# Altium schematic sheet ESP32.SchDoc(ESP32)
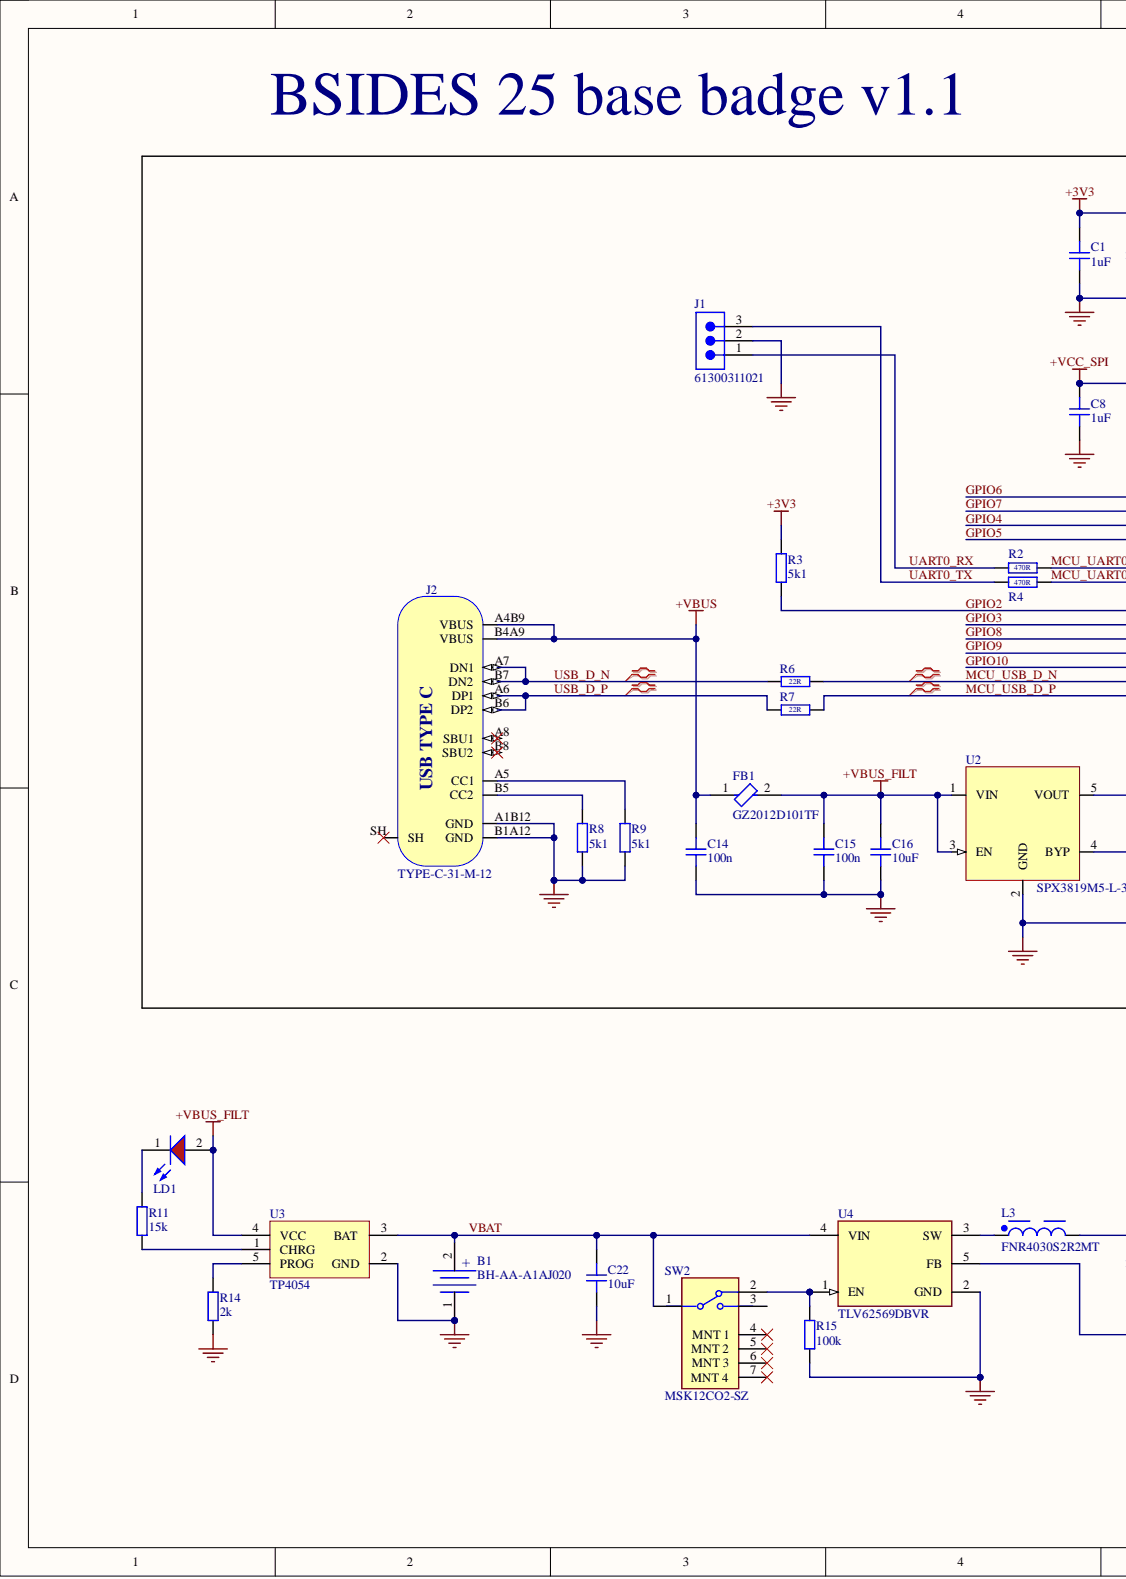
[diagram p0: ESP32.SchDoc, left]
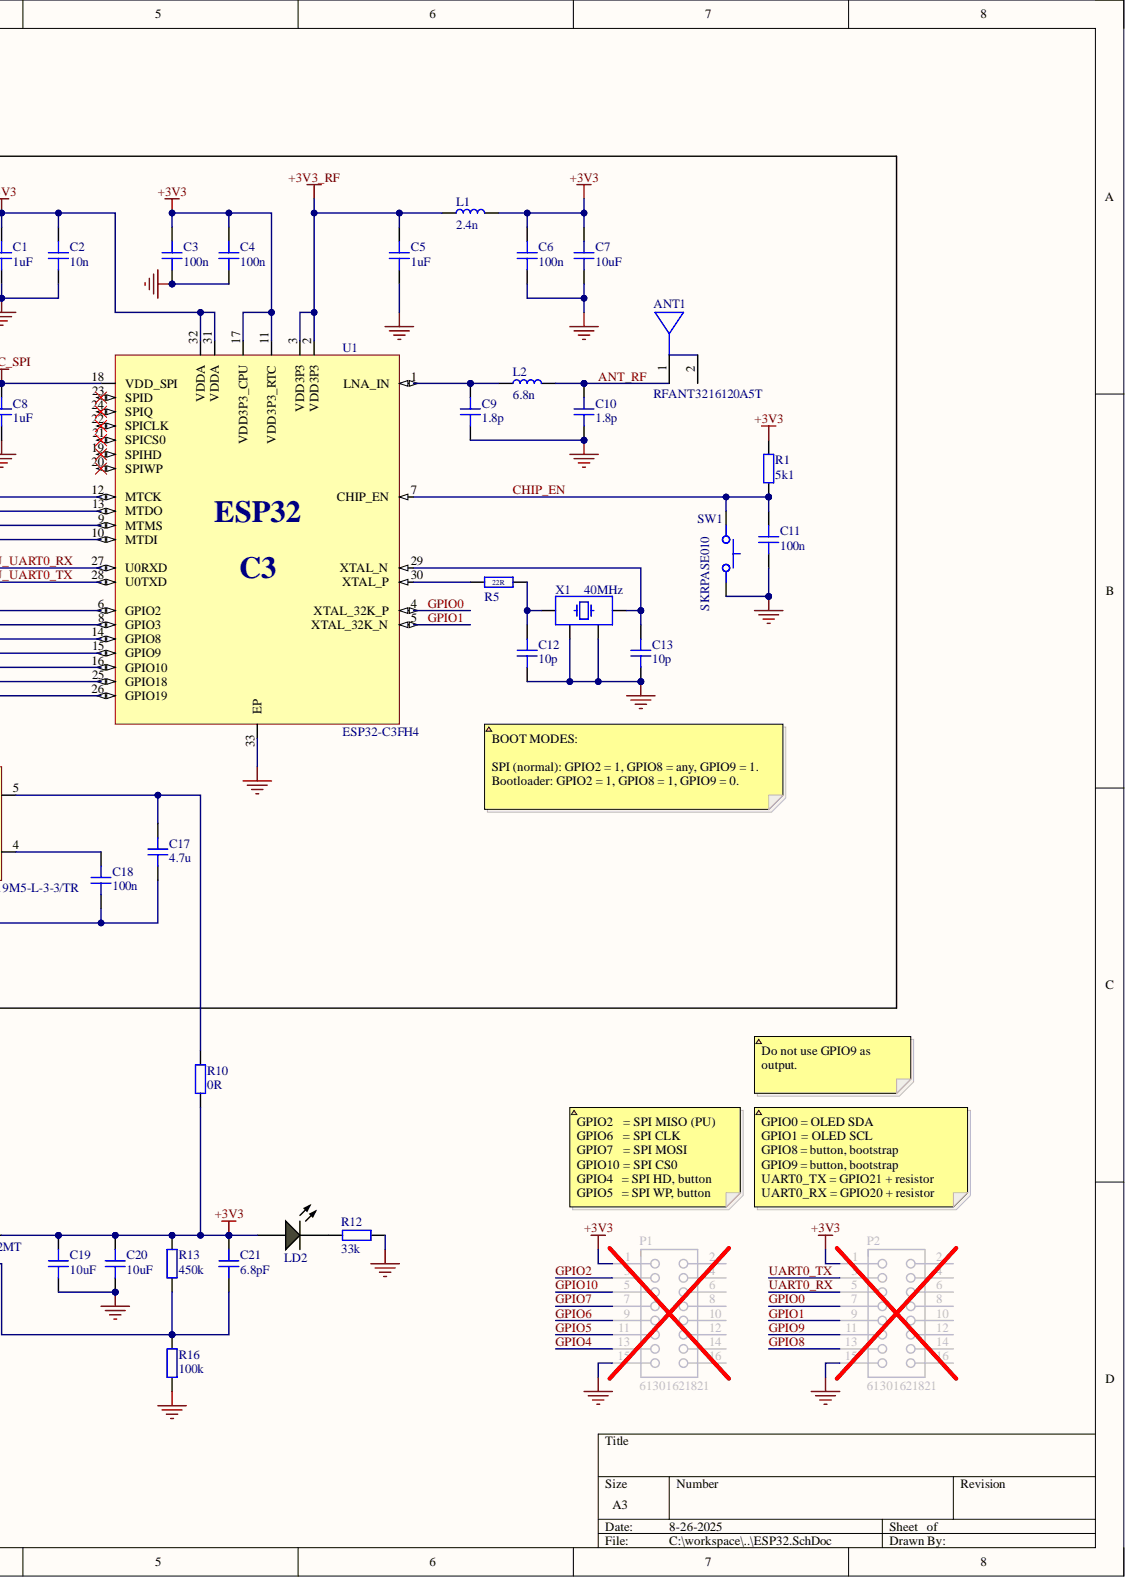
[diagram p1: ESP32.SchDoc, right]

## Parts
ANT1  RFANT3216120A5T  [FP-RFANT3216120A5T-MFG]
B1  BH-AA-A1AJ020  [BH-AA-A1AJ020]
C1  1uF  [CAP_0402]
C2  10n  [CAP_0402]
C3  100n  [CAP_0402]
C4  100n  [CAP_0402]
C5  1uF  [CAP_0402]
C6  100n  [CAP_0402]
C7  10uF  [CAP 0805 [2012]]
C8  1uF  [CAP_0402]
C9  1.8p  [CAP_0402]
C10  1.8p  [CAP_0402]
C11  100n  [CAP_0402]
C12  10p  [CAP_0402]
C13  10p  [CAP_0402]
C14  100n  [CAP_0402]
C15  100n  [CAP_0402]
C16  10uF  [CAP 0805 [2012]]
C17  4.7u  [CAP_0603]
C18  100n  [CAP_0402]
C19  10uF  [CAP 0805 [2012]]
C20  10uF  [CAP 0805 [2012]]
C21  6.8pF  [CAP 0402 [1005]]
C22  10uF  [CAP 0805 [2012]]
FB1  GZ2012D101TF  [FP-BLM21-0_2-t0_85-e0_5-d-MFG]
J1  61300311021  [61300311021]
J2  TYPE-C-31-M-12  [TYPE-C-31-M-12]
L1  2.4n  [IND_0402]
L2  6.8n  [IND_0402]
L3  FNR4030S2R2MT  [WE-PD2_4532]
LD1  NCD0805R1  [WL-SMCW_0805_150080xx75000]  (value not drawn)
LD2  KT-0805G  [AVAG-HSMX-C170_V]  (value not drawn)
P1  61301621821  [61301621821]
P2  61301621821  [61301621821]
R1  5k1  [RES_0402]
R2  470R  [RES_0402]
R3  5k1  [RES_0402]
R4  470R  [RES_0402]
R5  22R  [RES_0402]
R6  22R  [RES_0402]
R7  22R  [RES_0402]
R8  5k1  [RES_0402]
R9  5k1  [RES_0402]
R10  0R  [RES_0402]
R11  15k  [RES_0402]
R12  33k  [RES_0402]
R13  450k  [RES_0402]
R14  2k  [RES_0402]
R15  100k  [RES_0402]
R16  100k  [RES_0402]
SW1  SKRPASE010  [SKRPANE010]
SW2  MSK12CO2-SZ  [FP-CUS-12TB-MFG]
U1  ESP32-C3FH4  [QFN32-5x5]
U2  SPX3819M5-L-3-3/TR  [SOT-23-5]
U3  TP4054  [SOT-23-5]
U4  TLV62569DBVR  [FP-DBV0005A-MFG]
X1  40MHz  [XTAL_2520]

## Nets
+3V3: C1.2 C2.2 C3.2 C4.2 C6.2 C7.2 C19.2 C20.2 C21.2 L1.1 L3.2 LD2.1 P1.1 P2.1 R1.2 R3.1 R10.1 R13.1 U1.11(VDD3P3_RTC) U1.17(VDD3P3_CPU) U1.31(VDDA) U1.32(VDDA)
+3V3_RF: C5.2 L1.2 U1.2(VDD3P3) U1.3(VDD3P3)
+VBUS: C14.2 FB1.1 J2.A4B9(VBUS) J2.B4A9(VBUS)
+VBUS_FILT: C15.2 C16.2 FB1.2 LD1.2 U2.1(VIN) U2.3(EN) U3.4(VCC)
+VCC_SPI: C8.2 U1.18(VDD_SPI)
GND: B1.1 C1.1 C2.1 C3.1 C4.1 C5.1 C6.1 C7.1 C8.1 C9.1 C10.1 C11.1 C12.1 C13.1 C14.1 C15.1 C16.1 C17.1 C18.1 C19.1 C20.1 C22.1 J1.2 J2.A1B12(GND) J2.B1A12(GND) P1.15 P2.15 R8.2 R9.2 R12.2 R14.2 R15.2 R16.2 SW1.12 U1.33(EP) U2.2(GND) U3.2(GND) U4.2(GND) X1.2 X1.4
ANT_RF: ANT1.1 C10.2 L2.1
CHIP_EN: C11.2 R1.1 SW1.34 U1.7(CHIP_EN)
GPIO0: P2.7 U1.4(XTAL_32K_P)
GPIO1: P2.9 U1.5(XTAL_32K_N)
GPIO2: P1.3 R3.2 U1.6(GPIO2)
GPIO3: U1.8(GPIO3)
GPIO4: P1.13 U1.9(MTMS)
GPIO5: P1.11 U1.10(MTDI)
GPIO6: P1.9 U1.12(MTCK)
GPIO7: P1.7 U1.13(MTDO)
GPIO8: P2.13 U1.14(GPIO8)
GPIO9: P2.11 U1.15(GPIO9)
GPIO10: P1.5 U1.16(GPIO10)
MCU_UART0_RX: R2.2 U1.27(U0RXD)
MCU_UART0_TX: R4.2 U1.28(U0TXD)
MCU_USB_D_N: R6.2 U1.25(GPIO18)
MCU_USB_D_P: R7.2 U1.26(GPIO19)
UART0_RX: J1.1 P2.5 R2.1
UART0_TX: J1.3 P2.3 R4.1
USB_D_N: J2.A7(DN1) J2.B7(DN2) R6.1
USB_D_P: J2.A6(DP1) J2.B6(DP2) R7.1
VBAT: B1.2 C22.2 SW2.1 U3.3(BAT) U4.4(VIN)
NetC9_2: C9.2 L2.2 U1.1(LNA_IN)
NetC12_2: C12.2 R5.2 X1.3
NetC13_2: C13.2 U1.29(XTAL_N) X1.1
NetC17_2: C17.2 R10.2 U2.5(VOUT)
NetC18_2: C18.2 U2.4(BYP)
NetC21_1: C21.1 R13.2 R16.1 U4.5(FB)
NetJ2_A5: J2.A5(CC1) R9.1
NetJ2_B5: J2.B5(CC2) R8.1
NetL3_1: L3.1 U4.3(SW)
NetLD1_1: LD1.1 R11.1
NetLD2_2: LD2.2 R12.1
NetR5_1: R5.1 U1.30(XTAL_P)
NetR11_2: R11.2 U3.1(CHRG)
NetR14_1: R14.1 U3.5(PROG)
NetR15_1: R15.1 SW2.2 U4.1(EN)

Not connected: ANT1 2; J2 A8(SBU1) B8(SBU2) SH; P1 2 4 6 8 10 12 14 16; P2 2 4 6 8 10 12 14 16; SW2 3 4(MNT_1) 5(MNT_2) 6(MNT_3) 7(MNT_4); U1 19(SPIHD) 20(SPIWP) 21(SPICS0) 22(SPICLK) 23(SPID) 24(SPIQ)

## Library data of the parts
ANT1 = CMP-45725-000001-1: RF ANT 2.4GHZ CHIP SOLDER SMD; Manufacturer: Walsin Technology Corporation; Gain: 2dB; Max Frequency: 2500MHz; Number Of Bands: 1; Power Rating: 3W; Automotive: No; Bandwidth: 2.4GHz; Category: Ant; Component Kind: Standard; ComponentLink2Description: Datasheet; ComponentLink2URL: http://www.passivecomponent.com/wp-content/uploads/2013/12/ASC_RFANT3216120A5T_V09.pdf; Device Class L1: Integrated Circuits (ICs); Device Class L2: RF Semiconductors and Devices; Device Class L3: RF Antennas; Digikey Description: RF ANT 2.4GHZ CHIP SOLDER SMD; Height: 1.3mm; LCSC: C127629; Lead Free: Yes; Library Name: Altium Content Vault; Manufacturer Part Number: RFANT3216120A5T; Mouser Description: Antennas 2450+/-50MHz 2.9GHz Fc 2dBi; Octopart: https://octopart.com/rfant3216120a5t-walsin+technologies-20084619; Package: RFANT3216120A5T; RoHS: TRUE; Temperature: -40°C to +85°C; Temperature Range High: +85°C; Temperature Range Low: -40°C
B1 = BH-AA-A1AJ020: Battery box Size 5 - Button And Strip Battery Connector ROHS; Manufacturer: MYOUNG; Component Kind: Standard; LCSC: C5290181; Product name: BH-AA-A1AJ020; Supplier: JLCPCB; Library Name: BSides.SCHLIB
C1 C5 C8 = CAP_0402_50V: Capacitor SMD 0402 50V 1uF; Manufacturer: Samsung; Manufacturer Part Number: CL05A105KA5NQNC; Component Kind: Standard; LCSC: C52923; Library Name: Schematic-Lib-PhilsLab.SchLib
C2 = CAP_0402_50V: Capacitor SMD 0402 50V 10nF; Manufacturer: Samsung; Manufacturer Part Number: CL05B103KB5NNNC; Component Kind: Standard; LCSC: C15195; Library Name: Schematic-Lib-PhilsLab.SchLib
C3 C4 C6 C11 C14 C15 C18 = CAP_0402_50V: Capacitor SMD 0402 50V; Manufacturer: Samsung; Manufacturer Part Number: CL05B104KO5NNNC; Component Kind: Standard; LCSC: C1525; Library Name: Schematic-Lib-PhilsLab.SchLib
C7 C16 C19 C20 C22 = CAP: Capacitor SMD 0805 10uF 25V; Manufacturer: Samsung Electro-Mechanics; Manufacturer Part Number: CL21A106KAYNNNE; Component Kind: Standard; LCSC: C15850; Library Name: BSides_2024.SCHLIB
C9 C10 = CAP_0402_50V: Capacitor SMD 0402 50V 1.8pF; Manufacturer: Yageo; Manufacturer Part Number: CC0402BRNPO9BN1R8; Component Kind: Standard; LCSC: C327209; Library Name: Schematic-Lib-PhilsLab.SchLib
C12 C13 = CAP_0402_50V: Capacitor SMD 0402 50V; Manufacturer: Samsung; Manufacturer Part Number: CL05C100JB5NNNC; Component Kind: Standard; LCSC: C32949; Library Name: Schematic-Lib-PhilsLab.SchLib
C17 = CAP_0603_10V: Capacitor SMD 0603 10V; Manufacturer: Samsung; Manufacturer Part Number: CL10A475KO8NNNC; Component Kind: Standard; LCSC: C19666; Library Name: Schematic-Lib-PhilsLab.SchLib
C21 = CAP: 50V 6.8pF C0G 0402; Manufacturer: FH; Manufacturer Part Number: 0402CG6R8C500NT; Component Kind: Standard; LCSC: C1576; Library Name: BSides_2024.SCHLIB
FB1 = CMP-0686-00620-3: 100Ω@100MHz 0805  Ferrite Beads ROHS; Current Rating: 4A; DC Current: 3A; DC Resistance (DCR): 14mR; Frequency: 100MHz; Impedance: 30R; Material: Steel; Number of Circuits: 1; Resistance: 15mR; Shielding: Unshielded; Tolerance: 25%; Case Code (Imperial): 0805; Case Code (Metric): 2012; Case/Package: 0805; Component Kind: Standard; Core Material: Ferrite; DC Resistance-Max: 14mR; Depth: 1.25mm; Height: 0.85mm; LCSC: C1015; Lead Free: Lead Free; Length: 2mm; Library Name: Altium Content Vault; Max Current Rating: 4A; Max DC Current: 3A; Max Operating Temperature: 125°C; Military Standard: Not; Min Operating Temperature: -55°C; Number of Lines: 1; Number of Pins: 2; Package Quantity: 1; Packaging: Tape and Reel; Radiation Hardening: No; REACH SVHC: No SVHC; RoHS Compliant: Yes; Series: BLM21P; Termination: SMD/SMT; Test Frequency: 100MHz; Width: 1.25mm
J1 = CMP-1502-01061-3: NexarPartId: 32855520; Connector Type: Male Pin; Contact Resistance: 20mΩ; Current Rating: 3A; Gender: Male; Number of Contacts: 3; Operating Supply Voltage: 250V; Pitch: 2.54mm; Color: Black; Component Kind: Standard; Contact Material: Copper; Contact Mating Length: 5.9944mm; Contact Plating: Gold; LCSC: C492411; Lead Pitch: 2.54mm; Library Name: Altium Content Vault; Mount: Through Hole; Number of Pins: 3; Number of Positions: 3; Number of Rows: 1; Packaging: Bulk; REACH SVHC: Unknown; RoHS: Compliant; Termination: Solder
J2 = TYPE-C-31-M-12: USB Type C Connector Horizontal SMT; Manufacturer: Koren Hroparts; Component Kind: Standard; LCSC: C165948; Manufacturer Part Number: TYPE-C-31-M-12; Library Name: Schematic-Lib-PhilsLab.SchLib
L1 = IND_0402: Inductor SMD 0402 2.4nH; Manufacturer: Murata; Manufacturer Part Number: LQG15HS2N4S02D; Component Kind: Standard; LCSC: C97997; Library Name: Schematic-Lib-PhilsLab.SchLib
L2 = IND_0402: Inductor SMD 0402 6.8nH; Manufacturer: Murata; Manufacturer Part Number: LQG15HS6N8J02D; Component Kind: Standard; LCSC: C77110; Library Name: Schematic-Lib-PhilsLab.SchLib
L3 = CMP-0227-00517-2: 3.2A 2.2uH ±20% 5.8A SMD,4x4mm Power Inductors; NexarPartId: 7866441; Core Material: Ferrite; Current Rating: 1A; DC Resistance (DCR): 261mΩ; Inductance: 22µH; Resistance: 370mΩ; Saturation Current: 1.22A; Self Resonant Frequency: 20MHz; Shielding: Unshielded; Test Frequency: 10kHz; Ambient Temperature Range High: 85°C; Ambient Temperature Range Low: -40°C; Case/Package: Nonstandard; Component Kind: Standard; Composition: Wirewound; Height: 3.2mm; Height - Seated (Max): 3.5052mm; Inductance Tolerance: 20%; LCSC: C167869; Lead Free: Lead Free; Length: 4mm; Library Name: Altium Content Vault; Max DC Current: 1A; Max Operating Temperature: 125°C; Min Operating Temperature: -40°C; Mount: Surface Mount; Number of Pins: 2; Packaging: Cut Tape (CT); REACH SVHC: Unknown; RMS Current (Irms): 1A; RoHS: Compliant; Termination: SMD/SMT; Tolerance: 20%; Width: 4.5mm
LD1 = CMP-1426-00011-3: LED Uni-Color Red 630nm 2-Pin Chip 0805(2012Metric) T/R; Dominant Wavelength: 625nm; Forward Current: 100mA; Forward Voltage: 2V; Lens Style: Clear, Rectangular; Luminous Intensity: 150mcd; Max Junction Temperature (Tj): 95°C; Max Reverse Voltage (DC): 5V; Power Dissipation: 72mW; Test Current: 20mA; Viewing Angle: 140°; Case Code (Imperial): 0805; Case Code (Metric): 2012; Case/Package: 0805; Color: Red; Component Kind: Standard; Height: 700µm; LCSC: C84256; Length: 2mm; Library Name: Altium Content Vault; Max Operating Temperature: 85°C; Min Operating Temperature: -40°C; Mount: Surface Mount; Packaging: Tape and Reel; Peak Wavelength: 630nm; REACH SVHC: Unknown; RoHS: Compliant; Wavelength: 625nm; Width: 1.25mm
LD2 = CMP-2000-05062-1: Chip LED, Green, 2.2 V, -40 to 85 degC, 2-Pin SMD, Pb-Free, Tape and Reel; Manufacturer: Avago; Bulb Size: 1.25mmx1.4mm; Dominant Wavelength: 572nm; External Depth: 0.8mm; External Length / Height: 2mm; External Width: 1.25mm; Forward Current If: 20mA; Forward Voltage: 2.2V; Forward Voltage VF Max: 2.6V; LED Type: Standard; Lens Shape: Rectangular; Light Area Height: 1mm; Luminous Intensity: 15mcd; Luminous Intensity Min: 4mcd; No. of Pins: 2; Opto Mounting Orientation: Top; Peak Wavelength: 570nm; PIV Max: 5V; SVHC: NoSVHC(16-Jun-2014); Viewing Angle: 170deg; Component Kind: Standard; Datasheet URL: https://datasheet.ciiva.com/29280/180495-da-01-en-chip-led-hsmg-c170-gelb-gruen-29280971.pdf; Datasheet Version: Oct-08; Dominant Wavelength Typ: 572nm; Forward Current If Max: 20mA; LCSC: C2297; LED Colour: Green; LED Mounting: SMD; Library Name: Altium Content Vault; Luminous Intensity Typ: 15mcd; Manufacturer URL: http://www.avagotech.com/; Mounting Type: SMD; MSL: MSL2A-4weeks; Operating Temperature Max: 85degC; Operating Temperature Min: -30degC; Operating Temperature Range: -30degCto+85degC; Opto Case Style: SMD; Package Description: 2-Pin Surface Mount Device, Body 2 x 1.25 mm, Height 0.8 mm; Package Reference: HSMX-C170; Packaging: CutTape; Peak Forward Current: 20mA; Storage Temperature Max: 85degC; Storage Temperature Min: -40degC; Voltage Vf Typ: 2.2V; Wavelength Typ: 570nm
P1 P2 = CMP-1502-01344-3: NexarPartId: 61924738; Contact Resistance: 20mΩ; Current Rating: 3A; Gender: Female; Operating Supply Voltage: 250V; Component Kind: Standard; LCSC: C30734; Lead Pitch: 2.54mm; Library Name: Altium Content Vault; Number of Pins: 16; Orientation: Vertical; RoHS: Non-Compliant
R1 R3 R8 R9 = RES_0402: Resistor SMD 0402 5.1k; Manufacturer: Uniroyal; Manufacturer Part Number: 0402WGF5101TCE; Component Kind: Standard; LCSC: C25905; Library Name: Schematic-Lib-PhilsLab.SchLib
R2 R4 = RES_0402: Resistor SMD 0402; Manufacturer: UNI-ROYAL; Manufacturer Part Number: 0402WGF4700TCE; Component Kind: Standard; LCSC: C25117; Library Name: Schematic-Lib-PhilsLab.SchLib
R5-R7 = RES_0402: Resistor SMD 0402; Manufacturer: UNI-ROYAL; Manufacturer Part Number: 0402WGF220JTCE; Component Kind: Standard; LCSC: C25092; Library Name: Schematic-Lib-PhilsLab.SchLib
R10 = RES_0402: Resistor SMD 0402 0R; Manufacturer: Uniroyal; Manufacturer Part Number: 0402WGF0000TCE; Component Kind: Standard; LCSC: C17168; Library Name: Schematic-Lib-PhilsLab.SchLib
R11 = RES_0402: Resistor SMD 0402 15k; Manufacturer: Uniroyal; Manufacturer Part Number: 0402WGF1502TCE; Component Kind: Standard; LCSC: C25756; Library Name: Schematic-Lib-PhilsLab.SchLib
R12 = RES_0402: Resistor SMD 0402 33k; Manufacturer: Uniroyal; Manufacturer Part Number: 0402WGF3302TCE; Component Kind: Standard; LCSC: C25779; Library Name: Schematic-Lib-PhilsLab.SchLib
R13 = RES_0402: Resistor SMD 0402 450k; Manufacturer: FOJAN; Manufacturer Part Number: FRC0402F4503TS; Component Kind: Standard; LCSC: C5159688; Library Name: Schematic-Lib-PhilsLab.SchLib
R14 = RES_0402: Resistor SMD 0402 2k; Manufacturer: Uniroyal; Manufacturer Part Number: 0402WGF2001TCE; Component Kind: Standard; LCSC: C4109; Library Name: Schematic-Lib-PhilsLab.SchLib
R15 R16 = RES_0402: Resistor SMD 0402 100k; Manufacturer: Uniroyal; Manufacturer Part Number: 0402WGF1003TCE; Component Kind: Standard; LCSC: C25741; Library Name: Schematic-Lib-PhilsLab.SchLib
SW1 = SKRPANE010: Tactile Switch SMD SPST; Manufacturer: Alps Alpine; Component Kind: Standard; LCSC: C470427; Manufacturer Part Number: SKRPASE010; Library Name: Schematic-Lib-PhilsLab.SchLib
SW2 = CMP-71745-000005-2: SWITCH SLIDE SPDT 300MA 4V; Actuator Length: 1.5mm; Actuator Material: Nylon; Actuator Type: Standard; Contact Style: Non-Shorting; Electrical Life: 10000Cycles; Max Voltage Rating (DC): 20V; Operating Force: 204gf; Switch Function: On-On; Component Kind: Standard; Contact Material: Copper Alloy; Contact Plating: Gold; Current Rating: 300mA; LCSC: C2681568; Library Name: Altium Content Vault; Max Operating Temperature: 70°C; Min Operating Temperature: -20°C; Mount: Surface Mount; Orientation: Right Angle; Packaging: Tape and Reel; Radiation Hardening: No; RoHS Compliant: Yes; Series: CUS; Switch Travel: 1.5mm; Termination: Gull Wing; Throw Configuration: SPDT; Voltage Rating (DC): 4V
U1 = ESP32-C3: Ultra­Low­Power SoC with RISC­V Single­Core CPU Supporting IEEE 802.11b/g/n (2.4 GHz Wi­Fi) and Bluetooth® 5 (LE); Manufacturer: Espressif; Component Kind: Standard; LCSC: C2858491; Manufacturer Part Number: ESP32-C3; Library Name: Schematic-Lib-PhilsLab.SchLib
U2 = SPX3819M5-L-3-3/TR: 500mA +3.3V Low-Noise LDO Voltage Regulator; Manufacturer: MaxLinear; Component Kind: Standard; LCSC: C9055; Manufacturer Part Number: SPX3819M5-L-3-3/TR; Library Name: Schematic-Lib-PhilsLab.SchLib
U3 = TP4054: 4V~9V Lithium-Ion/Polymer 1 800mA SOT-23-5  Battery Management ROHS; Component Kind: Standard; LCSC: C5381776; Product name: TP4054; Library Name: BSides.SCHLIB
U4 = CMP-04918-000387-1: IC REG BUCK ADJ 2A SOT23-5; Max Input Voltage: 5.5V; Min Input Voltage: 2.5V; Min Output Voltage: 600mV; Output Configuration: Positive; Output Type: Adjustable; Switching Frequency: 1.5MHz; Synchronous Rectifier: Yes; Case/Package: SOT-23-5; Component Kind: Standard; LCSC: C141836; Library Name: Altium Content Vault; Max Operating Temperature: 125°C; Max Output Voltage: 5.5V; Min Operating Temperature: -40°C; Mount: Surface Mount; Output Current: 2A; Packaging: Cut Tape; RoHS Compliant: Yes
X1 = XTAL_2520: Crystal SMD 2520; Manufacturer: Shenzhen SCTF Elec; Manufacturer Number: SX2B40.000F1010F30; Component Kind: Standard; Frequency: 40MHz; LCSC: C7301994; Library Name: Schematic-Lib-PhilsLab.SchLib
